# Altium schematic sheet B2B_Connectors.SchDoc(B2B_Connectors)
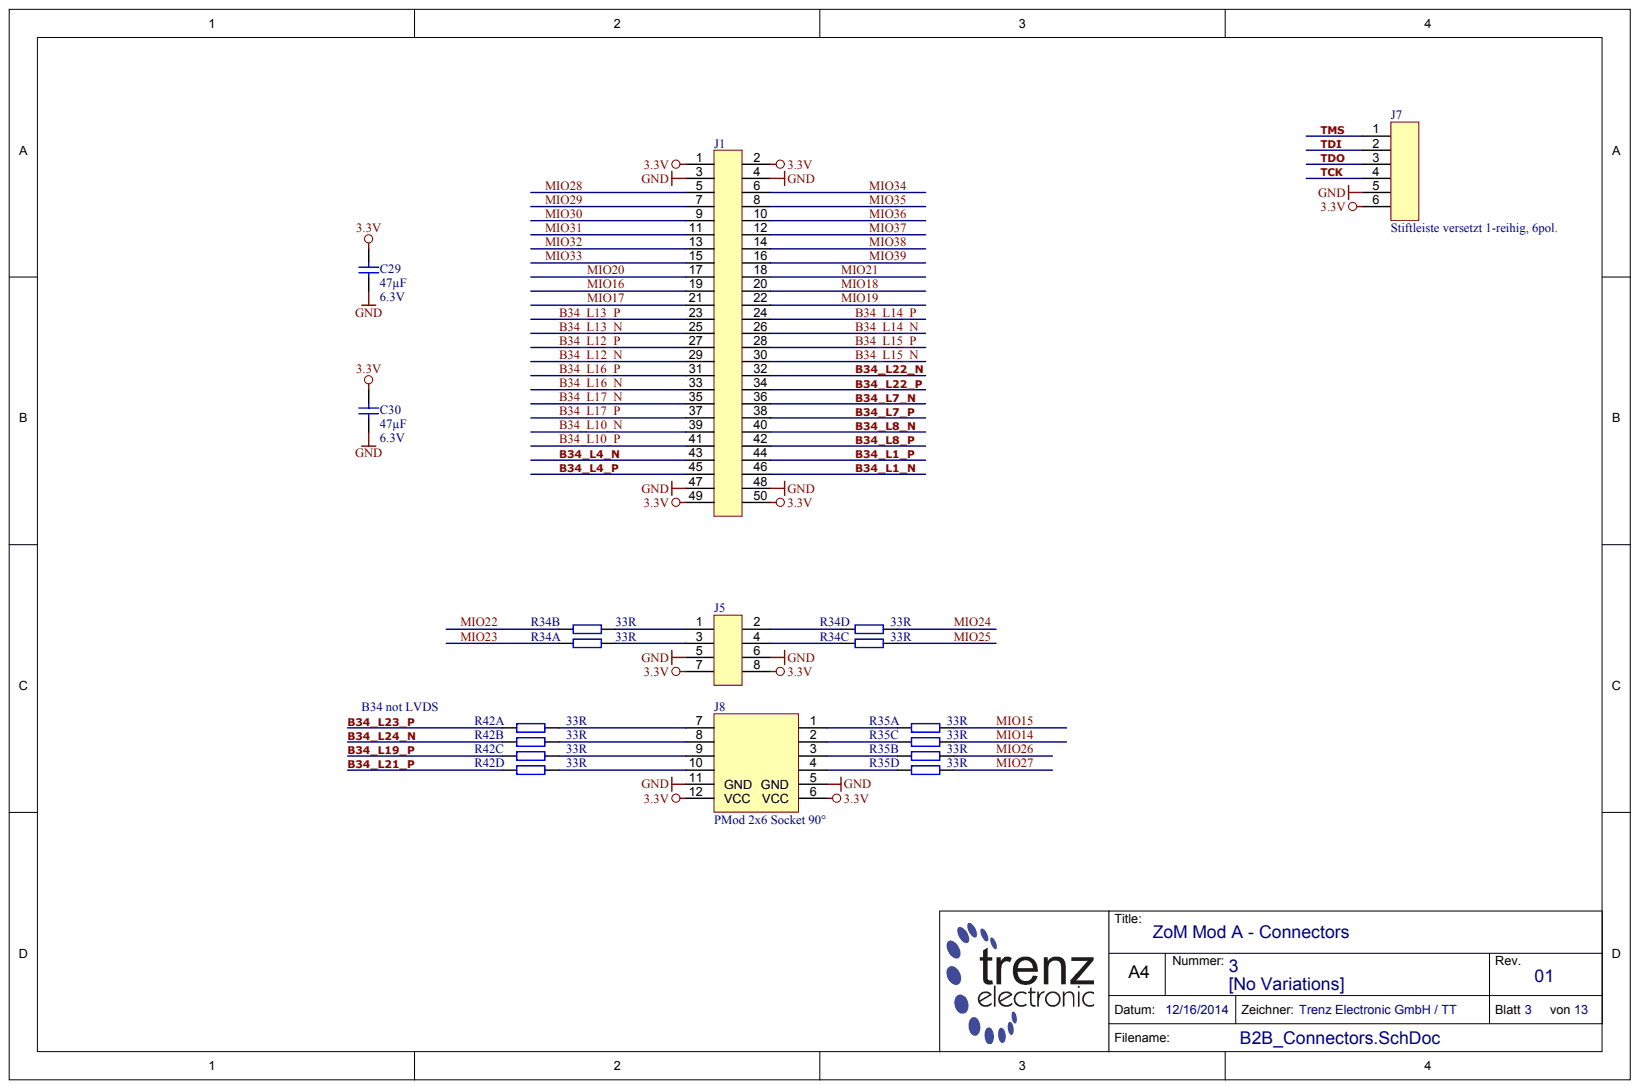
[diagram p0: B2B_Connectors.SchDoc]

## Parts
C29  47µF  Voltage: 6.3V  [CAPC3216X180N]
C30  47µF  Voltage: 6.3V  [CAPC3216X180N]
J1  WANNE2,54-50 GERADE  [WANNE2,54-50 GERADE]  (value not drawn)
J5  STIFTLEISTE2.54 OG 2R-8  [STIFTLEISTE2_54 OG 2R-8]  (value not drawn)
J7  Stiftleiste versetzt 1-reihig, 6pol.  [STIFTLEISTE2_54 OG 1R-6V]
J8  PMod 2x6 Socket 90°  [PMod2x6]
R34  33R  [RESCAX50P100X200X55-8N]  (parts A,B,C,D)
R35  33R  [RESCAX50P100X200X55-8N]  (parts A,B,C,D)
R42  33R  [RESCAX50P100X200X55-8N]  (parts A,B,C,D)

## Nets
3.3V: C29.1 C30.1 J1.1 J1.2 J1.49 J1.50 J5.7 J5.8 J7.6 J8.6(VCC) J8.12(VCC)
GND: C29.2 C30.2 J1.3 J1.4 J1.47 J1.48 J5.5 J5.6 J7.5 J8.5(GND) J8.11(GND)
B34_L1_N: J1.46
B34_L1_P: J1.44
B34_L4_N: J1.43
B34_L4_P: J1.45
B34_L7_N: J1.36
B34_L7_P: J1.38
B34_L8_N: J1.40
B34_L8_P: J1.42
B34_L10_N: J1.39
B34_L10_P: J1.41
B34_L12_N: J1.29
B34_L12_P: J1.27
B34_L13_N: J1.25
B34_L13_P: J1.23
B34_L14_N: J1.26
B34_L14_P: J1.24
B34_L15_N: J1.30
B34_L15_P: J1.28
B34_L16_N: J1.33
B34_L16_P: J1.31
B34_L17_N: J1.35
B34_L17_P: J1.37
B34_L19_P: R42.3
B34_L21_P: R42.4
B34_L22_N: J1.32
B34_L22_P: J1.34
B34_L23_P: R42.1
B34_L24_N: R42.2
MIO14: R35.6
MIO15: R35.8
MIO16: J1.19
MIO17: J1.21
MIO18: J1.20
MIO19: J1.22
MIO20: J1.17
MIO21: J1.18
MIO22: R34.7
MIO23: R34.8
MIO24: R34.5
MIO25: R34.6
MIO26: R35.7
MIO27: R35.5
MIO28: J1.5
MIO29: J1.7
MIO30: J1.9
MIO31: J1.11
MIO32: J1.13
MIO33: J1.15
MIO34: J1.6
MIO35: J1.8
MIO36: J1.10
MIO37: J1.12
MIO38: J1.14
MIO39: J1.16
TCK: J7.4
TDI: J7.2
TDO: J7.3
TMS: J7.1
NetJ5_1: J5.1 R34.2
NetJ5_2: J5.2 R34.4
NetJ5_3: J5.3 R34.1
NetJ5_4: J5.4 R34.3
NetJ8_1: J8.1 R35.1
NetJ8_2: J8.2 R35.3
NetJ8_3: J8.3 R35.2
NetJ8_4: J8.4 R35.4
NetJ8_7: J8.7 R42.8
NetJ8_8: J8.8 R42.7
NetJ8_9: J8.9 R42.6
NetJ8_10: J8.10 R42.5

## Library data of the parts
C29 C30 = 24557: KON 47µF 6.3V  X5R SMD 20%; Database Table Name: C_3216_1206; Mixed: SMT; Preferred: Yes; Value: 47u; AN: 24557; Case: 3216 (1206); Component Kind: Standard; Library Name: TE.DbLib; Technology: X5R; Tolerance: 20%
J1 = 20131: Stiftleiste Wanne 50polig; Database Table Name: CON_HEADER; Mixed: THT; Trenz-Stock: Yes; AN: 20131; Component Kind: Standard; Library Name: TE.DbLib; HelpURL: B:\AD\adlib\datasheet\137
J5 = 23904: Stiftleiste gerade 2-reihig, 8pol.; Database Table Name: CON_HEADER; Mixed: THT; Trenz-Stock: Yes; AN: 23904; Component Kind: Standard; Library Name: TE.DbLib
J7 = 22911: Database Table Name: CON_HEADER; Mixed: THT; Trenz-Stock: Yes; AN: 22911; Component Kind: Standard; Description: Stiftleiste versetzt 1-reihig, 6pol.; Library Name: TE.DbLib
J8 = 25215: Database Table Name: CON_IO; Trenz-Article-Number: 23185; Trenz-Stock: Yes; AN: 25215; Component Kind: Standard; Description: PMod 2x6 Socket 90°; Library Name: TE.DbLib
R34 R35 R42 = 23422: WID SMD Netz 4 fach 33R; Database Table Name: R_NET; Mixed: SMT; Preferred: Yes; Value: 33; AN: 23422; Case: 0402x4 0804; Component Kind: Standard; Library Name: TE.DbLib; HelpURL: B:\AD\adlib\datasheet\MNR04
